# Altium schematic sheet FCC Footprint Charge Controller.SchDoc
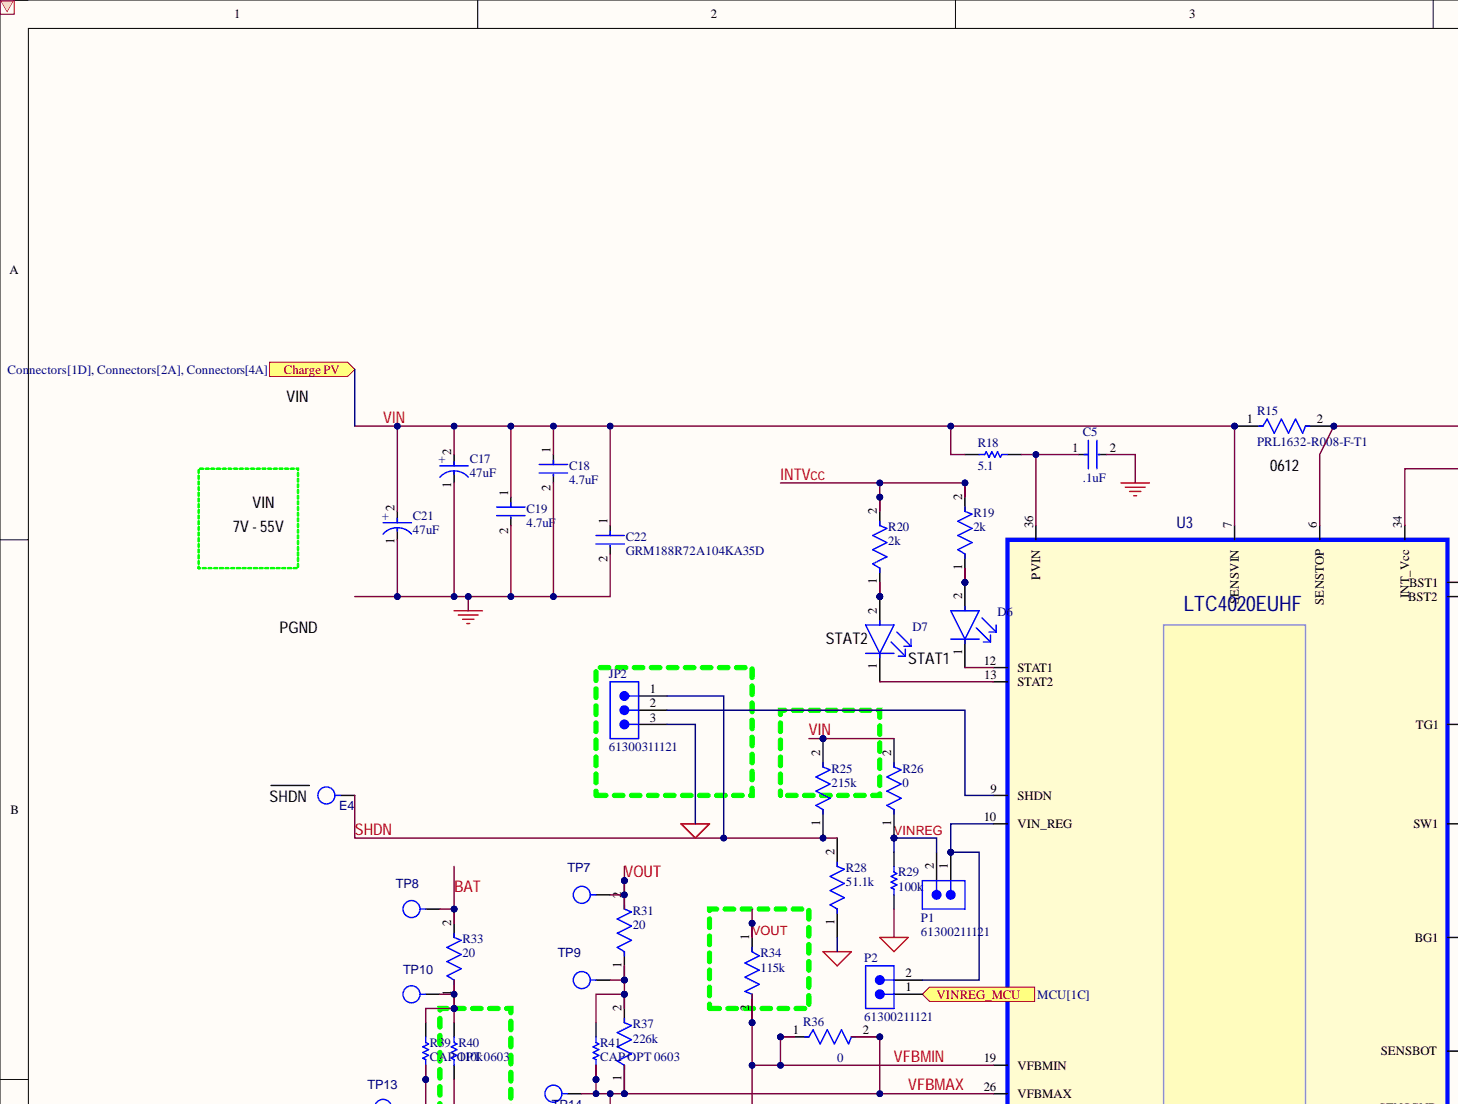
[diagram p0: FCC Footprint Charge Controller.SchDoc, top left]
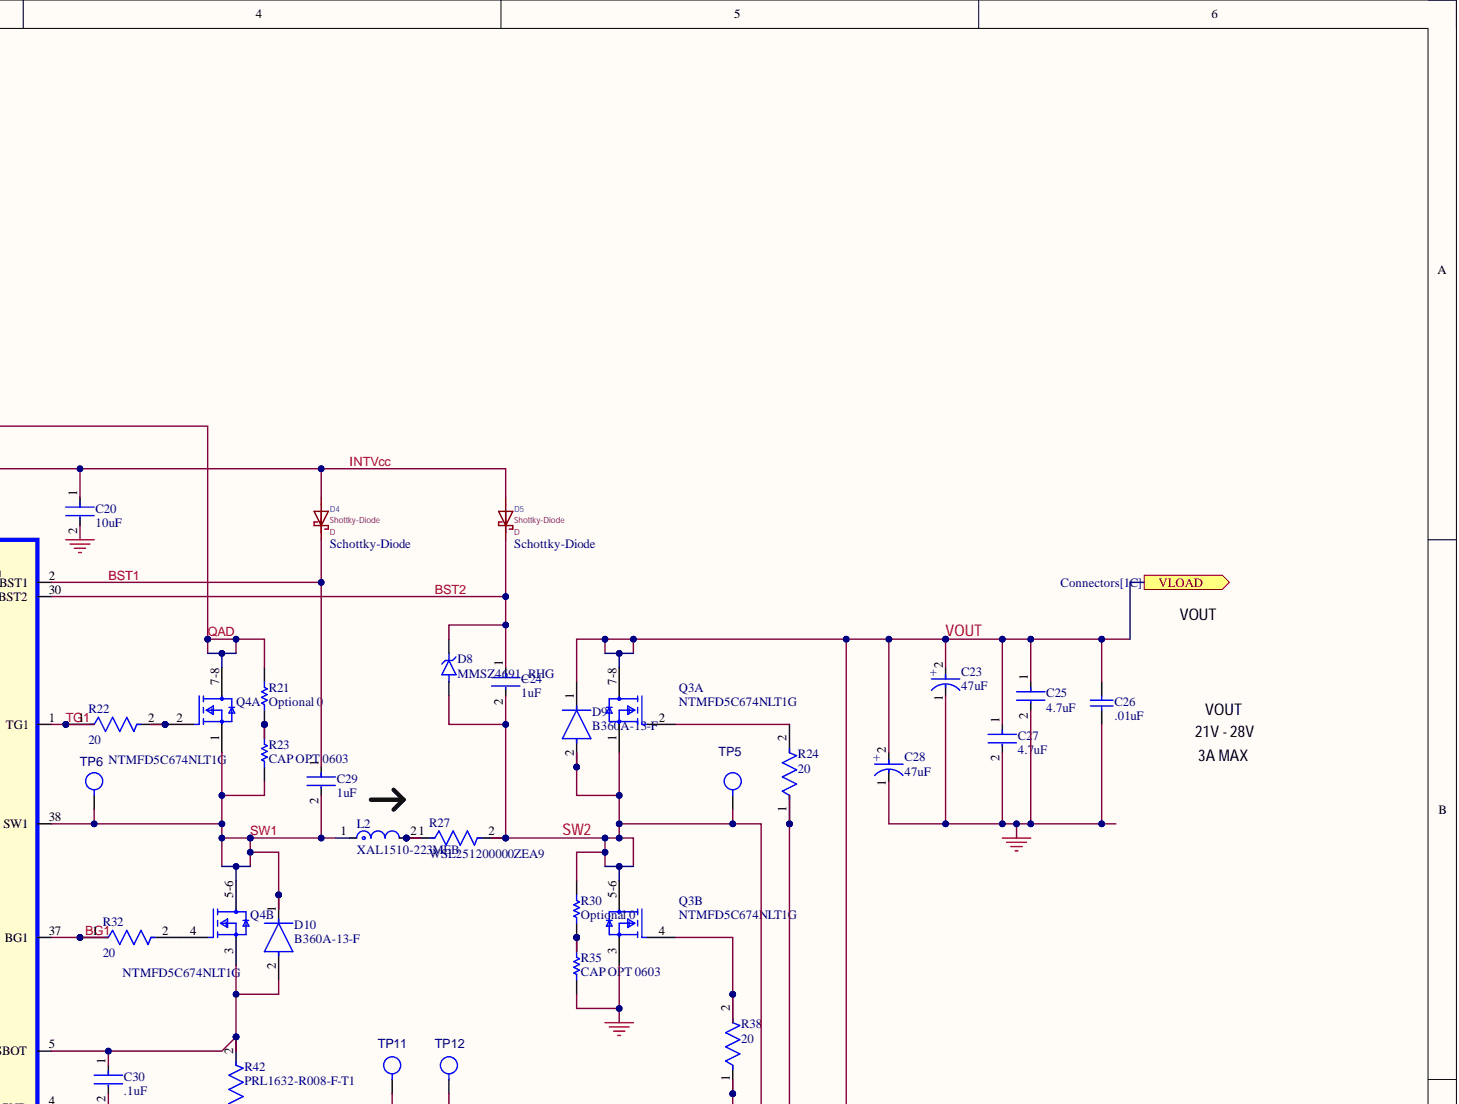
[diagram p1: FCC Footprint Charge Controller.SchDoc, top right]
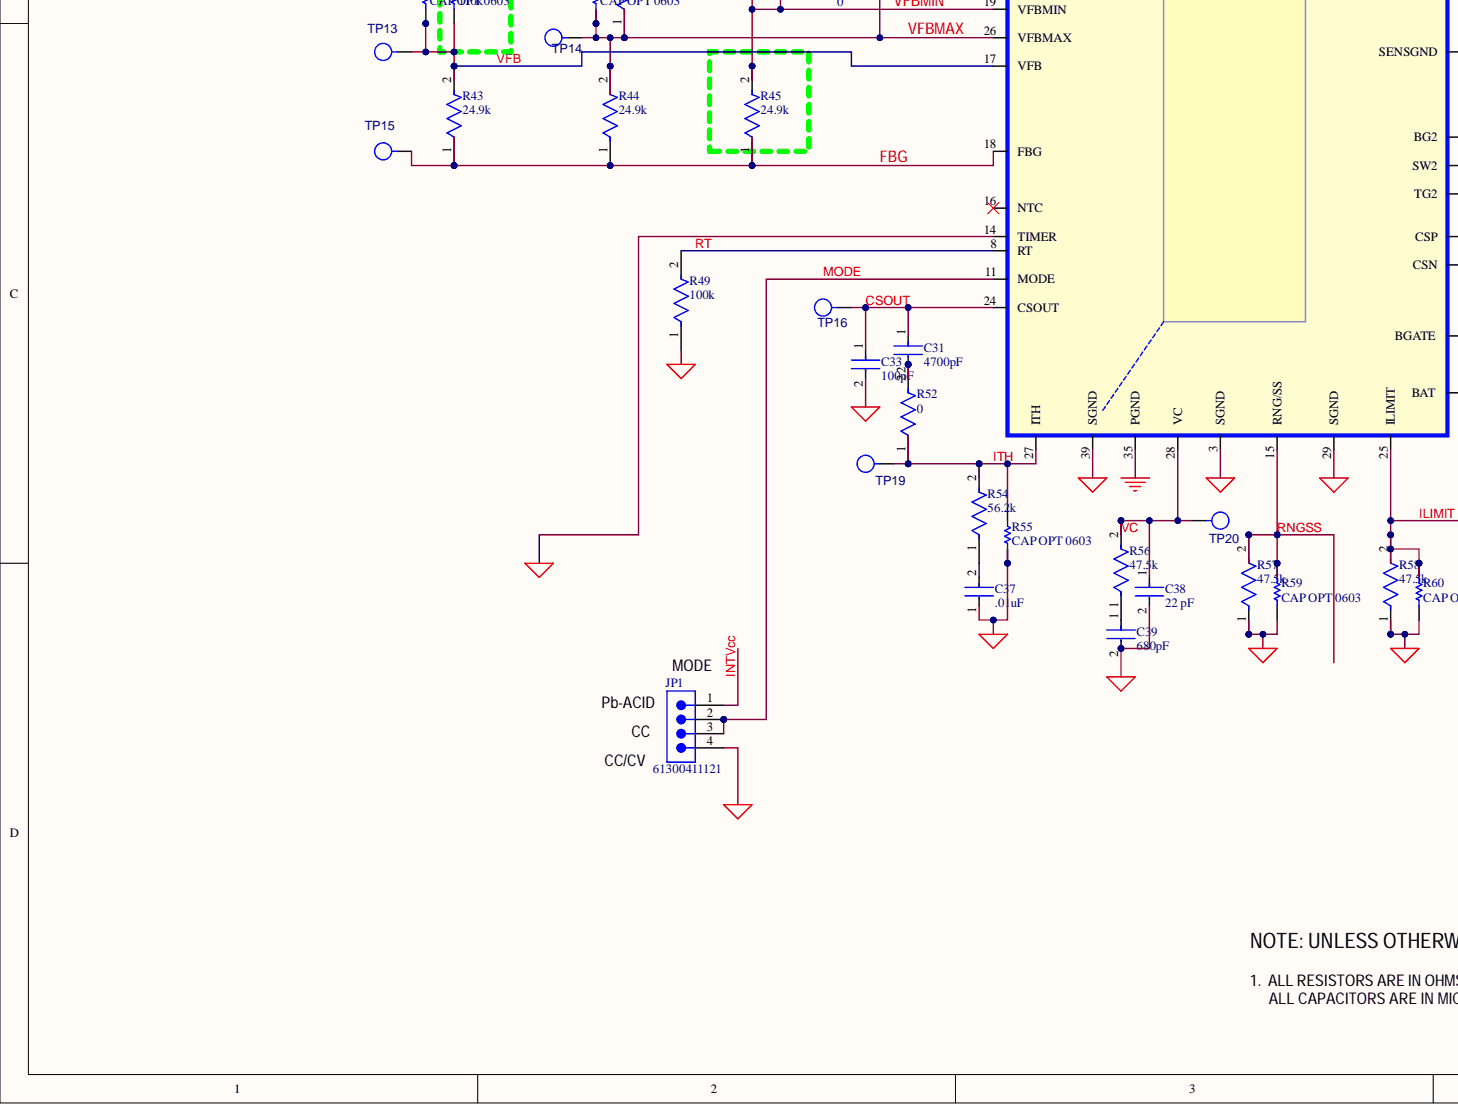
[diagram p2: FCC Footprint Charge Controller.SchDoc, bottom left]
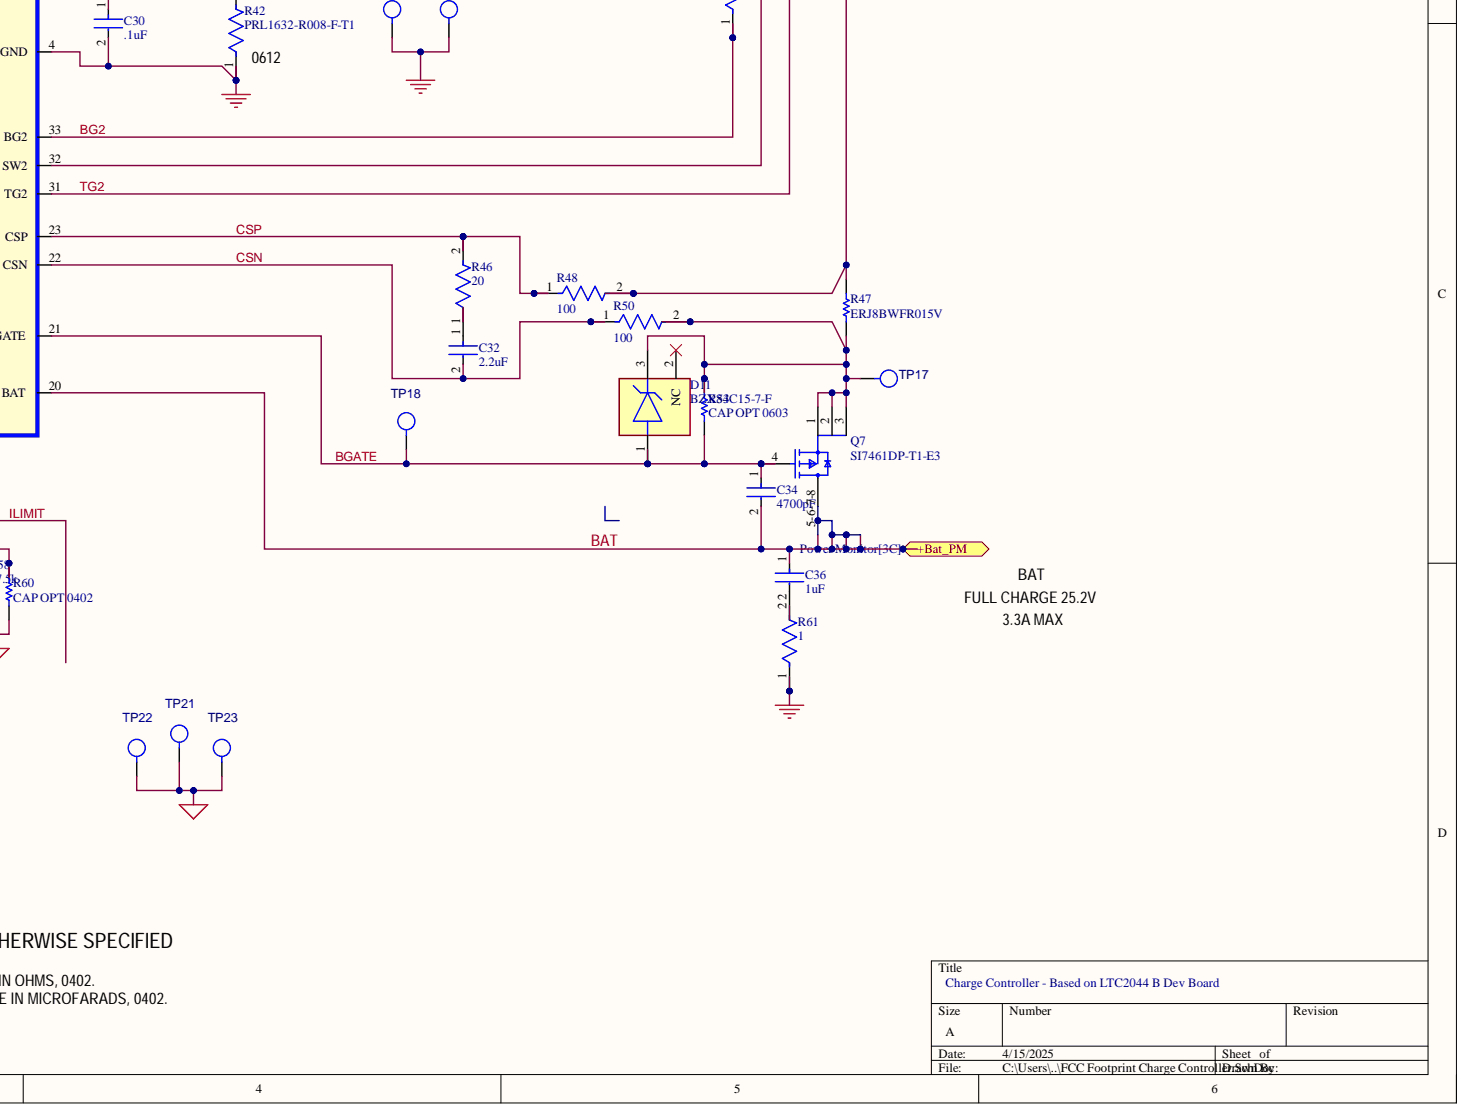
[diagram p3: FCC Footprint Charge Controller.SchDoc, bottom right]

## Parts
C5  .1uF  [FP-GRM188-0_1-e0_2_0_5-IPC_A]
C17  47uF  [FP-FKF-MFG]
C18  4.7uF  [FP-CGA6P-IPC_C]
C19  4.7uF  [FP-CGA6P-IPC_C]
C20  10uF  [FP-CGA4J-IPC_A]
C21  47uF  [FP-FKF-MFG]
C22  GRM188R72A104KA35D  [FP-GRM188-0_1-e0_2_0_5-IPC_B]
C23  47uF  [FP-FKF-MFG]
C24  1uF  [FP-C0603C-CJ-MFG]
C25  4.7uF  [FP-CGA6P-IPC_C]
C26  .01uF  [CAPC1608X92X38NL15T08]
C27  4.7uF  [FP-CGA6P-IPC_C]
C28  47uF  [FP-FKF-MFG]
C29  1uF  [FP-C0603C-CJ-MFG]
C30  .1uF  [FP-GCM155-0_05-IPC_A]
C31  4700pF  [FP-GRM155-0_05-IPC_B]
C32  2.2uF  [FP-GRM188-0_1-e0_2_0_5-IPC_A]
C33  100pF  [FP-C0402-BB-MFG]
C34  4700pF  [FP-GRM155-0_05-IPC_B]
C36  1uF  [FP-GCJ31C-0_3-IPC_A]
C37  .01uF  [FP-GCJ188-0_2-IPC_A]
C38  22 pF  [FP-C0603C-CF-MFG]
C39  680pF  [FP-C0603C-CF-MFG]
D4  Schottky-Diode  value Shottky-Diode  Reference: D
D5  Schottky-Diode  value Shottky-Diode  Reference: D
D6  (no value)  [FP-APT1608MGC-MFG]
D7  (no value)  [FP-APTD1608SURCK-MFG]
D8  MMSZ4691_RHG  [DIO_MMSZ4691_RHG]
D9  B360A-13-F  [FP-SMA-MFG]
D10  B360A-13-F  [FP-SMA-MFG]
D11  BZX84C15-7-F  [FP-SOT23-MFG]
E4  TEST POINT  [MILLMAX2308]  (value not drawn)
JP1  61300411121  [61300411121]
JP2  61300311121  [61300311121]
L2  XAL1510-223MEB  [FP-XAL1510-223MEB-MFG]
P1  61300211121  [61300211121]
P2  61300211121  [61300211121]
Q3  NTMFD5C674NLT1G  [FP-506BT-MFG]  (parts A,B)
Q4  NTMFD5C674NLT1G  [FP-506BT-MFG]  (parts A,B)
Q7  SI7461DP-T1-E3  [FP-S17-0173-SINGLE-MFG]
R15  PRL1632-R008-F-T1  [FP-PRL1632-MFG]
R18  5.1  [RESC2013X50X30LL20T20]
R19  2k  [FP-CRCW0402-e3-IPC_A]
R20  2k  [FP-CRCW0402-e3-IPC_A]
R21  Optional 0  [RESC2013X50X30LL20T20]
R22  20  [FP-CRCW0402-C-e3-MFG]
R23  CAP OPT 0603  [RESC1608X55X25ML10T15]
R24  20  [FP-CRCW0402-C-e3-MFG]
R25  215k  [FP-CRCW0402-e3-MFG]
R26  0  [FP-RC0402-0_4-MFG]
R27  WSL251200000ZEA9  [FP-WSL2512-MFG]
R28  51.1k  [FP-RC_L0402-MFG]
R29  100k  [RESC1005X40X25NL10T10]
R30  Optional 0  [RESC2013X50X30LL20T20]
R31  20  [FP-AC0603-MFG]
R32  20  [FP-CRCW0402-C-e3-MFG]
R33  20  [FP-AC0603-MFG]
R34  115k  [FP-RC1608-IPC_A]
R35  CAP OPT 0603  [RESC1608X55X25ML10T15]
R36  0  [FP-CRCW0402-e3-IPC_C]
R37  226k  Case Code (Imperial): 0603  [FP-CRCW0603-e3-IPC_C]
R38  20  [FP-CRCW0402-C-e3-MFG]
R39  CAP OPT 0603  [RESC1608X55X25ML10T15]
R40  110k  [RESC1608X55X30NL20T20]
R41  CAP OPT 0603  [RESC1608X55X25ML10T15]
R42  PRL1632-R008-F-T1  [FP-PRL1632-MFG]
R43  24.9k  [FP-CRCW0603-e3-IPC_B]
R44  24.9k  [FP-CRCW0603-e3-IPC_B]
R45  24.9k  [FP-CRCW0603-e3-IPC_B]
R46  20  [FP-CRCW0402-C-e3-MFG]
R47  ERJ8BWFR015V  [RESC3216X70X50NL05T20]
R48  100  [FP-RT0402-0_35-IPC_C]
R49  100k  Series: RT  [FP-RT0402-MFG]
R50  100  [FP-RT0402-0_35-IPC_C]
R52  0  [FP-CRCW0402-e3-IPC_C]
R53  CAP OPT 0603  [RESC1608X55X25ML10T15]
R54  56.2k  [FP-CR0603-IPC_C]
R55  CAP OPT 0603  [RESC1608X55X25ML10T15]
R56  47.5k  Case Code (Imperial): 0603  [FP-RMCF0603-IPC_C]
R57  47.5k  [FP-RMCF0603-IPC_C]
R58  47.5k  Case Code (Imperial): 0603  [FP-RMCF0603-IPC_C]
R59  CAP OPT 0603  [RESC1608X55X25ML10T15]
R60  CAP OPT 0402  [RESC1005X40X25LL05T05]
R61  1  [FP-CRCW0805-e3-IPC_A]
TP5  TEST PAD  [PADSTYLE1]  (value not drawn)
TP6  TEST PAD  [PADSTYLE1]  (value not drawn)
TP7  TEST PAD  [PADSTYLE1]  (value not drawn)
TP8  TEST PAD  [PADSTYLE1]  (value not drawn)
TP9  TEST PAD  [PADSTYLE1]  (value not drawn)
TP10  TEST PAD  [PADSTYLE1]  (value not drawn)
TP11  TEST PAD  [PADSTYLE1]  (value not drawn)
TP12  TEST PAD  [PADSTYLE1]  (value not drawn)
TP13  Part Reference: TP13  [PADSTYLE1]  (hidden comment: TEST PAD)
TP14  TEST PAD  [PADSTYLE1]  (value not drawn)
TP15  TEST PAD  [PADSTYLE1]  (value not drawn)
TP16  TEST PAD  [PADSTYLE1]  (value not drawn)
TP17  TEST PAD  [PADSTYLE1]  (value not drawn)
TP18  TEST PAD  [PADSTYLE1]  (value not drawn)
TP19  TEST PAD  [PADSTYLE1]  (value not drawn)
TP20  TEST PAD  [PADSTYLE1]  (value not drawn)
TP21  Part Reference: TP21  [PADSTYLE1]  (hidden comment: TEST PAD)
TP22  TEST PAD  [PADSTYLE1]  (value not drawn)
TP23  TEST PAD  [PADSTYLE1]  (value not drawn)
U3  LTC4020EUHF  [FP-UHF-38-05-08-1701-IPC_A]

## Ports
+Bat_PM, Charge PV, VINREG_MCU, VLOAD

## Nets
GND: C5.2 C17.1 C18.2 C19.2 C20.2 C21.1 C22.2 C23.1 C25.2 C26.1 C27.2 C28.1 C30.2 Q3.3 R35.1 R42.1 R61.1 TP11.1 TP12.1 U3.4(SENSGND) U3.35(PGND)
GND_SIGNAL: C33.2 C37.1 C38.2 C39.2 JP1.4 JP2.3 R28.1 R29.2 R49.1 R55.1 R57.1 R58.1 R59.1 R60.1 TP21.1 TP22.1 TP23.1 U3.3(SGND) U3.14(TIMER) U3.29(SGND) U3.39(SGND)
BAT: C34.2 C36.1 Q7.5-6-7-8 R33.2 TP8.1 U3.20(BAT) ; port +Bat_PM
BG1: R32.1 U3.37(BG1)
BG2: R38.1 U3.33(BG2)
BGATE: C34.1 D11.1 Q7.4 R53.1 TP18.1 U3.21(BGATE)
BST1: C29.1 D4.K U3.2(BST1)
BST2: C24.1 D5.K D8.C U3.30(BST2)
CSN: C32.2 R50.1 U3.22(CSN)
CSOUT: C31.1 C33.1 TP16.1 U3.24(CSOUT)
CSP: R46.2 R48.1 U3.23(CSP)
FBG: R43.1 R44.1 R45.1 TP15.1 U3.18(FBG)
ILIMIT: R58.2 R60.2 U3.25(ILIMIT)
INTVcc: C20.1 D4.A D5.A JP1.1 R19.2 R20.2 U3.34(INT_Vcc)
ITH: R52.1 R54.2 R55.2 TP19.1 U3.27(ITH)
MODE: JP1.2 JP1.3 U3.11(MODE)
QAD: Q4.7-8 R15.2 R21.2 U3.6(SENSTOP)
RNGSS: R57.2 R59.2 U3.15(RNG/SS)
RT: R49.2 U3.8(RT)
SHDN: E4.1 JP2.1 R25.1 R28.2
SW1: C29.2 D10.1 L2.1 Q4.1 Q4.5-6 R23.1 TP6.1 U3.38(SW1)
SW2: C24.2 D8.A D9.2 Q3.1 Q3.5-6 R27.2 R30.2 TP5.1 U3.32(SW2)
TG1: R22.1 U3.1(TG1)
TG2: R24.1 U3.31(TG2)
VC: C38.1 R56.2 TP20.1 U3.28(VC)
VFB: R39.1 R40.1 R43.2 TP13.1 U3.17(VFB)
VFBMAX: R36.2 R37.1 R41.1 R44.2 TP14.1 U3.26(VFBMAX)
VFBMIN: R34.2 R36.1 R45.2 U3.19(VFBMIN)
VIN: C17.2 C18.1 C19.1 C21.2 C22.1 R15.1 R18.1 R25.2 R26.2 U3.7(SENSVIN) ; port Charge PV
VINREG: P1.2 R26.1 R29.1
VINREG_MCU: P2.1 ; port VINREG_MCU
VOUT: C23.2 C25.1 C26.2 C27.1 C28.2 D9.1 Q3.7-8 R31.2 R34.1 R47.2 R48.2 TP7.1 ; port VLOAD
NetC5_1: C5.1 R18.2 U3.36(PVIN)
NetC30_1: C30.1 D10.2 Q4.3 R42.2 U3.5(SENSBOT)
NetC31_2: C31.2 R52.2
NetC32_1: C32.1 R46.1
NetC36_2: C36.2 R61.2
NetC37_2: C37.2 R54.1
NetC39_1: C39.1 R56.1
NetD6_1: D6.1 U3.12(STAT1)
NetD6_2: D6.2 R19.1
NetD7_1: D7.1 U3.13(STAT2)
NetD7_2: D7.2 R20.1
NetD11_3: D11.3 Q7.1 Q7.2 Q7.3 R47.1 R50.2 R53.2 TP17.1
NetJP2_2: JP2.2 U3.9(SHDN)
NetL2_2: L2.2 R27.1
NetP1_1: P1.1 P2.2 U3.10(VIN_REG)
NetQ3_2: Q3.2 R24.2
NetQ3_4: Q3.4 R38.2
NetQ4_2: Q4.2 R22.2
NetQ4_4: Q4.4 R32.2
NetR21_1: R21.1 R23.2
NetR30_1: R30.1 R35.2
NetR31_1: R31.1 R37.2 R41.2 TP9.1
NetR33_1: R33.1 R39.2 R40.2 TP10.1

Not connected: D11 2; U3 16(NTC)
